# Altium schematic sheet 6_Connector.SchDoc
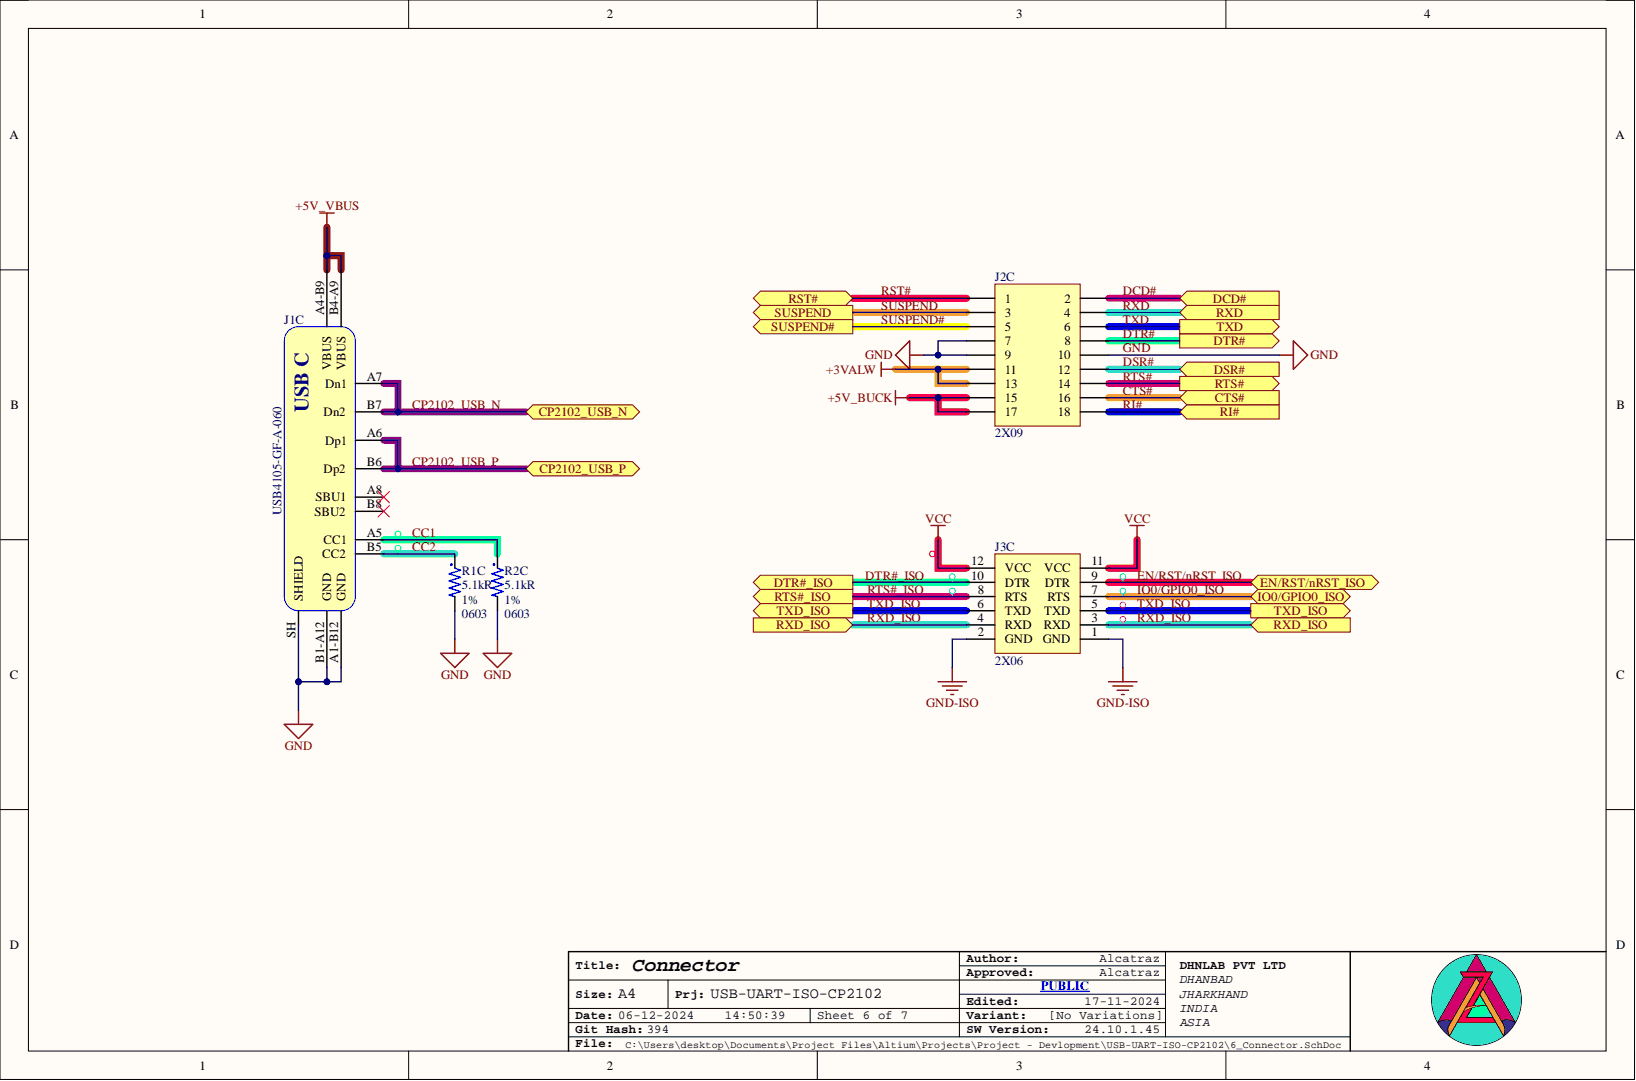
[diagram p0: 6_Connector.SchDoc]

## Parts
J1C  USB4105-GF-A-060  [USB-Type-C-16-Pin-SMT]
J2C  2X09  [PinHeader_2x09_P2.54mm_Vertical]
J3C  2X06  [PinHeader_2x06_P2.54mm_Vertical]
R1C  value 5.1kR  Case Code (Imperial): 0603  Resistance Tolerance: 1%  [R0603-MFG]  (hidden comment: CRCW06035K10FKEA)
R2C  value 5.1kR  Case Code (Imperial): 0603  Resistance Tolerance: 1%  [R0603-MFG]  (hidden comment: CRCW06035K10FKEA)

## Ports
CP2102_USB_N, CP2102_USB_P, CTS#, DCD#, DSR#, DTR#, DTR#_ISO, EN/RST/nRST_ISO, IO0/GPIO0_ISO, RI#, RST#, RTS#, RTS#_ISO, RXD, RXD_ISO, SUSPEND, SUSPEND#, TXD, TXD_ISO

## Nets
+3VALW: J2C.11 J2C.13
+5V_BUCK: J2C.15 J2C.17
+5V_VBUS: J1C.A4-B9(VBUS) J1C.B4-A9(VBUS)
GND-ISO: J3C.1(GND) J3C.2(GND)
VCC: J3C.11(VCC) J3C.12(VCC)
CC1: J1C.A5(CC1) R2C.1
CC2: J1C.B5(CC2) R1C.1
CP2102_USB_N: J1C.A7(Dn1) J1C.B7(Dn2) ; port CP2102_USB_N
CP2102_USB_P: J1C.A6(Dp1) J1C.B6(Dp2) ; port CP2102_USB_P
CTS#: J2C.16 ; port CTS#
DCD#: J2C.2 ; port DCD#
DSR#: J2C.12 ; port DSR#
DTR#: J2C.8 ; port DTR#
DTR#_ISO: J3C.10(DTR) ; port DTR#_ISO
EN/RST/nRST_ISO: J3C.9(DTR) ; port EN/RST/nRST_ISO
GND: J1C.A1-B12(GND) J1C.B1-A12(GND) J1C.SH(SHIELD) J2C.7 J2C.9 J2C.10 R1C.2 R2C.2
IO0/GPIO0_ISO: J3C.7(RTS) ; port IO0/GPIO0_ISO
RI#: J2C.18 ; port RI#
RST#: J2C.1 ; port RST#
RTS#: J2C.14 ; port RTS#
RTS#_ISO: J3C.8(RTS) ; port RTS#_ISO
RXD: J2C.4 ; port RXD
RXD_ISO: J3C.3(RXD) J3C.4(RXD) ; port RXD_ISO
SUSPEND: J2C.3 ; port SUSPEND
SUSPEND#: J2C.5 ; port SUSPEND#
TXD: J2C.6 ; port TXD
TXD_ISO: J3C.5(TXD) J3C.6(TXD) ; port TXD_ISO

Not connected: J1C A8(SBU1) B8(SBU2)

## Library data of the parts
J1C = USB-Type-C-16-Pin-SMT: USB Connector Type C SMT 16 Pin  (Power pins joints = 12 pins); Manufacturer: GCT; Component Kind: Standard; Library Name: Lib_Connector.IntLib; Manufacturer Part Number: USB4105-GF-A-060
J2C = PinHeader 2X09 DU: Component Kind: Standard; Library Name: Lib_Connector_PinHeader_2.54mm.IntLib
J3C = UART_2x06_P2.54mm_V2: Component Kind: Standard; Library Name: Lib_Connector_PinHeader_2.54mm.IntLib
R1C R2C = 5.1K: SMD Chip Resistor, 5.1 kOhm, ± 1%, 100 mW, 0603 [1608 Metric], Thick Film, General Purpose; Manufacturer: Vishay; Max Power Dissipation: 100mW; Temperature Coefficient: 100ppm/°C; Voltage Rating: 75V; Case Code (Metric): 1608; Case/Package: 0603; Category: Chip SMD Resistors; Component Kind: Standard; ComponentLink1Description: https://datasheet.ciiva.com/11809/0900766b80d98afc-11809935.pdf?src-supplier=Rs; ComponentLink1URL: https://datasheet.ciiva.com/11809/0900766b80d98afc-11809935.pdf?src-supplier=Rs; ComponentLink2Description: https://datasheet.ciiva.com/7690/1720486-7690873.pdf?src-supplier=Element14; ComponentLink2URL: https://datasheet.ciiva.com/7690/1720486-7690873.pdf?src-supplier=Element14; ComponentLink3Description: https://datasheet.ciiva.com/15159/getdatasheetpartid-32793-15159338.pdf?src-supplier=Verical; ComponentLink3URL: https://datasheet.ciiva.com/15159/getdatasheetpartid-32793-15159338.pdf?src-supplier=Verical; ComponentLink4Description: https://datasheet.ciiva.com/26314/fld-crcw-e3-data-en-26314742.pdf?src-supplier=Distrelec; ComponentLink4URL: https://datasheet.ciiva.com/26314/fld-crcw-e3-data-en-26314742.pdf?src-supplier=Distrelec; ComponentLink5Description: https://datasheet.ciiva.com/7690/1697337-7690728.pdf?src-supplier=Element14; ComponentLink5URL: https://datasheet.ciiva.com/7690/1697337-7690728.pdf?src-supplier=Element14; ComponentLink6Description: https://datasheet.ciiva.com/3257/dcrcwe3-3257758.pdf?src-supplier=Avnet; ComponentLink6URL: https://datasheet.ciiva.com/3257/dcrcwe3-3257758.pdf?src-supplier=Avnet; ComponentLink7Description: https://datasheet.ciiva.com/16226/dcrcwe3-16226862.pdf?src-supplier=Future+Electronics; ComponentLink7URL: https://datasheet.ciiva.com/16226/dcrcwe3-16226862.pdf?src-supplier=Future+Electronics; Construction: Rectangular; Contact Plating: Tin; Depth: 0.85mm; Features: Automotive AEC-Q200; Height: 0.45mm; Lead Free: 5697LeadFree; Length: 1.55mm; Library Name: Lib_Register_0603.IntLib; Manufacturer Part Number: CRCW06035K10FKEA; Max Operating Temperature: 155°C; Military Standard: Not; Min Operating Temperature: -55°C; Number of Terminations: 2; Package Quantity: 5000; Packaging: Tape and Reel; Power Rating: 100mW; Pricing 1: 1=0.1, 10=0.034, 50=0.034, 100=0.014, 1000=0.008, 10000=0.004 (USD); Radiation Hardening: No; Reach SVHC Compliant: Unknown; Resistor Type: Thick Film; RoHS: Yes; RoHS Compliant: Yes; Series: CRCW; Stock 1: 43654; Supplier 1: Mouser; Supplier Part Number 1: 71-CRCW0603-5.1K-E3; Technology: THICK FILM; Termination: SMD/SMT; Tolerance: 1%; Voltage Rating (DC): 75V; Weight: 7.1E-05oz; Width: 0.85mm
